# Altium schematic sheet 04_Connectors.SchDoc(04_Connectors)
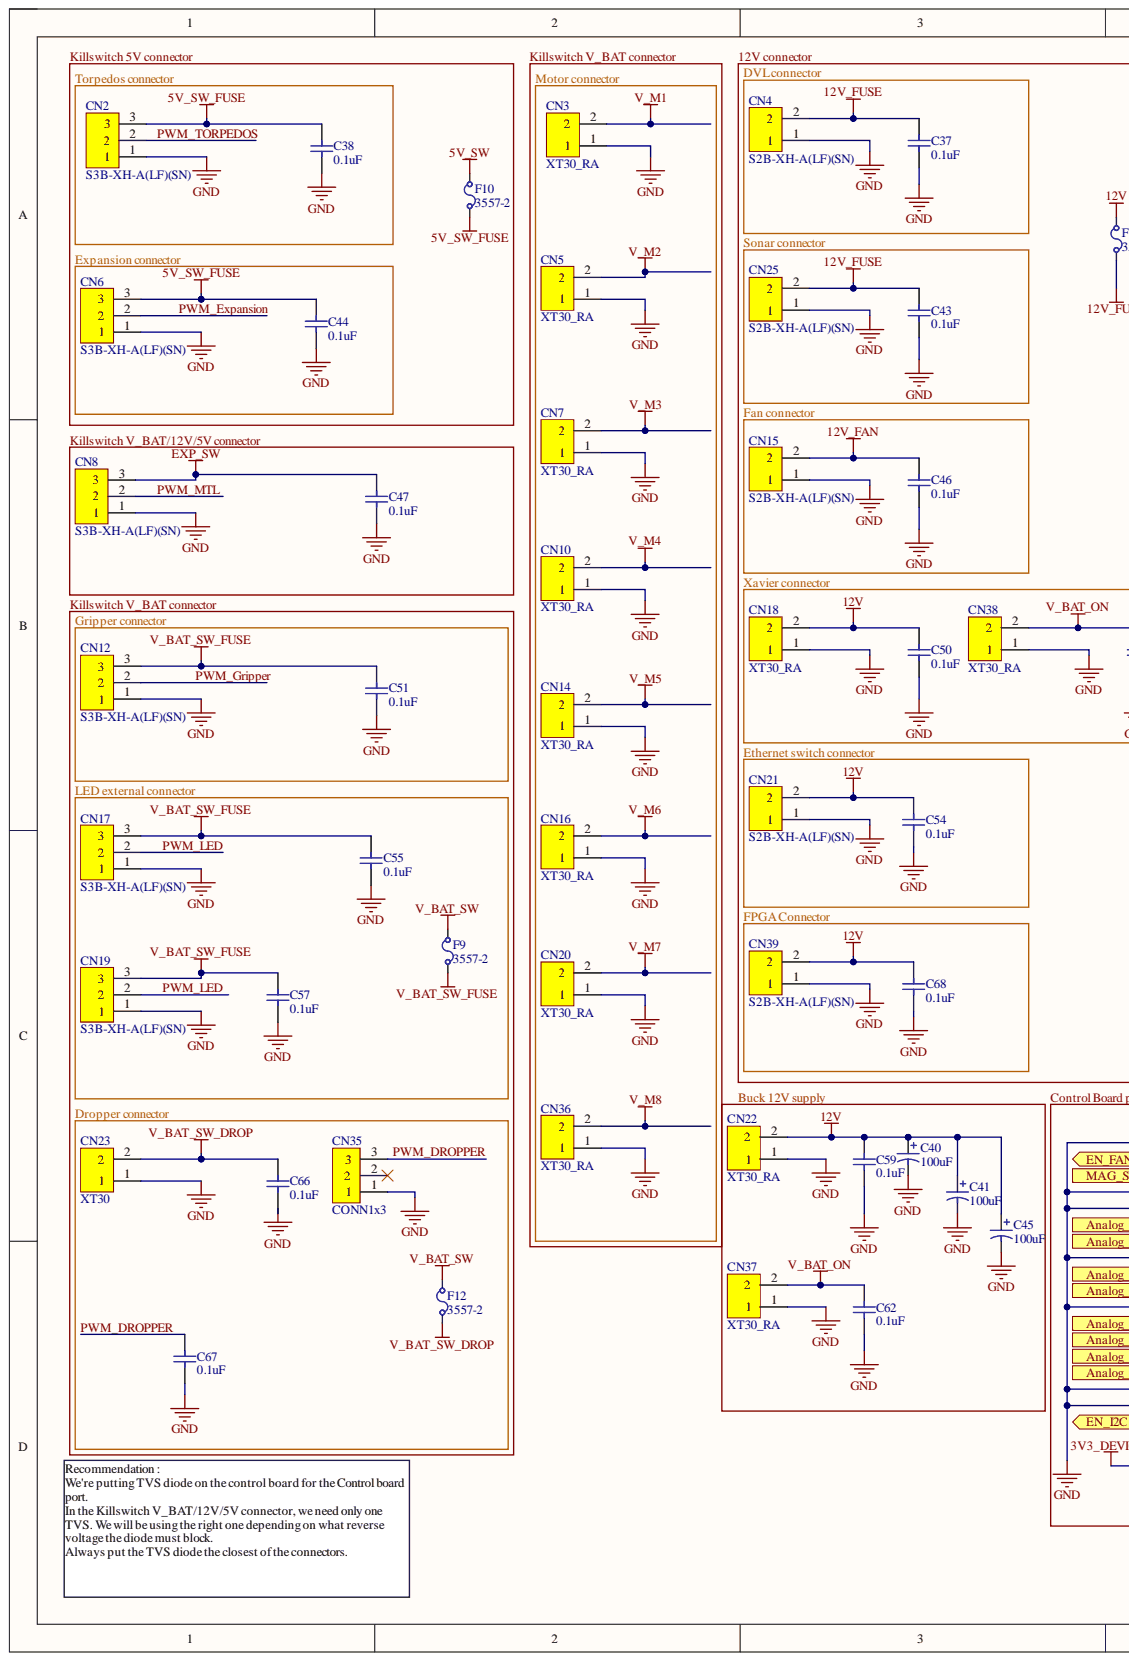
[diagram p0: 04_Connectors.SchDoc, left]
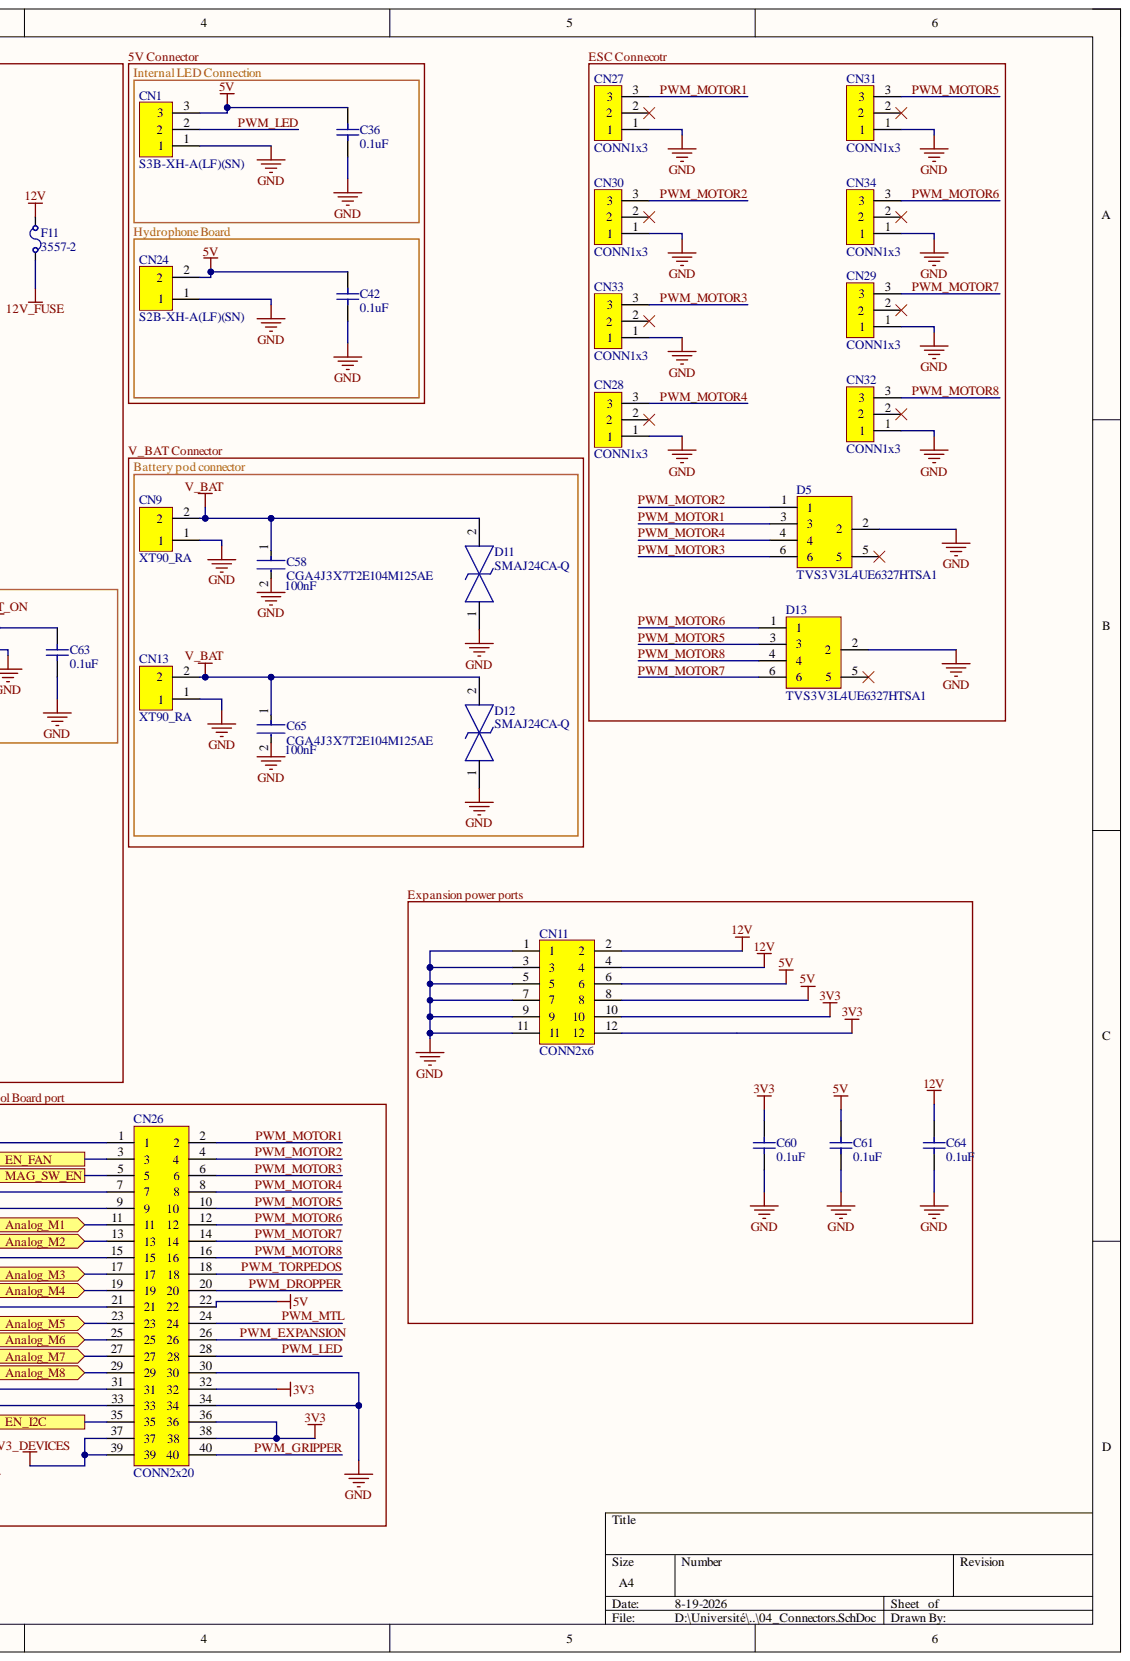
[diagram p1: 04_Connectors.SchDoc, right]

## Parts
C36  0.1uF  [CAPC200X125X145L50N]
C37  0.1uF  [CAPC200X125X145L50N]
C38  0.1uF  [CAPC200X125X145L50N]
C40  100uF  [CAPAE660X615D730L260X70N]
C41  100uF  [CAPAE660X615D730L260X70N]
C42  0.1uF  [CAPC200X125X145L50N]
C43  0.1uF  [CAPC200X125X145L50N]
C44  0.1uF  [CAPC200X125X145L50N]
C45  100uF  [CAPAE660X615D730L260X70N]
C46  0.1uF  [CAPC200X125X145L50N]
C47  0.1uF  [CAPC200X125X145L50N]
C50  0.1uF  [CAPC200X125X145L50N]
C51  0.1uF  [CAPC200X125X145L50N]
C54  0.1uF  [CAPC200X125X145L50N]
C55  0.1uF  [CAPC200X125X145L50N]
C57  0.1uF  [CAPC200X125X145L50N]
C58  CGA4J3X7T2E104M125AE  Capacitance: 100nF  [FP-CGA4J-IPC_C]  (no BOM)
C59  0.1uF  [CAPC200X125X145L50N]
C60  0.1uF  [CAPC200X125X145L50N]  (no BOM)
C61  0.1uF  [CAPC200X125X145L50N]  (no BOM)
C62  0.1uF  [CAPC200X125X145L50N]
C63  0.1uF  [CAPC200X125X145L50N]
C64  0.1uF  [CAPC200X125X145L50N]  (no BOM)
C65  CGA4J3X7T2E104M125AE  Capacitance: 100nF  [FP-CGA4J-IPC_C]  (no BOM)
C66  0.1uF  [CAPC200X125X145L50N]  (no BOM)
C67  0.1uF  [CAPC200X125X145L50N]  (no BOM)
C68  0.1uF  [CAPC200X125X145L50N]
CN1  S3B-XH-A(LF)(SN)  [JST_S3B-XH-A(LF)(SN)]  (no BOM)
CN2  S3B-XH-A(LF)(SN)  [JST_S3B-XH-A(LF)(SN)]  (no BOM)
CN3  XT30_RA  [XT30-90DEG]  (no BOM)
CN4  S2B-XH-A(LF)(SN)  [SHDRRA2W64P250_2X1_740X1150H610]  (no BOM)
CN5  XT30_RA  [XT30-90DEG]  (no BOM)
CN6  S3B-XH-A(LF)(SN)  [JST_S3B-XH-A(LF)(SN)]  (no BOM)
CN7  XT30_RA  [XT30-90DEG]  (no BOM)
CN8  S3B-XH-A(LF)(SN)  [JST_S3B-XH-A(LF)(SN)]  (no BOM)
CN9  XT90_RA  [XT90-90DEG]  (no BOM)
CN10  XT30_RA  [XT30-90DEG]  (no BOM)
CN11  CONN2x6  [RHDRV12W60P254_6X2_1575X495H851]  (no BOM)
CN12  S3B-XH-A(LF)(SN)  [JST_S3B-XH-A(LF)(SN)]  (no BOM)
CN13  XT90_RA  [XT90-90DEG]  (no BOM)
CN14  XT30_RA  [XT30-90DEG]  (no BOM)
CN15  S2B-XH-A(LF)(SN)  [SHDRRA2W64P250_2X1_740X1150H610]  (no BOM)
CN16  XT30_RA  [XT30-90DEG]  (no BOM)
CN17  S3B-XH-A(LF)(SN)  [JST_S3B-XH-A(LF)(SN)]  (no BOM)
CN18  XT30_RA  [XT30-90DEG]  (no BOM)
CN19  S3B-XH-A(LF)(SN)  [JST_S3B-XH-A(LF)(SN)]  (no BOM)
CN20  XT30_RA  [XT30-90DEG]  (no BOM)
CN21  S2B-XH-A(LF)(SN)  [SHDRRA2W64P250_2X1_740X1150H610]  (no BOM)
CN22  XT30_RA  [XT30-90DEG]  (no BOM)
CN23  XT30  [XT30 vertical]  (no BOM)
CN24  S2B-XH-A(LF)(SN)  [SHDRRA2W64P250_2X1_740X1150H610]  (no BOM)
CN25  S2B-XH-A(LF)(SN)  [SHDRRA2W64P250_2X1_740X1150H610]  (no BOM)
CN26  CONN2x20  [RHDRV40W102P254_20X2_5131X495H1613]  (no BOM)
CN27  CONN1x3  [HDRV3W60P254_3X1_813X254H851]  (no BOM)
CN28  CONN1x3  [HDRV3W60P254_3X1_813X254H851]  (no BOM)
CN29  CONN1x3  [HDRV3W60P254_3X1_813X254H851]  (no BOM)
CN30  CONN1x3  [HDRV3W60P254_3X1_813X254H851]  (no BOM)
CN31  CONN1x3  [HDRV3W60P254_3X1_813X254H851]  (no BOM)
CN32  CONN1x3  [HDRV3W60P254_3X1_813X254H851]  (no BOM)
CN33  CONN1x3  [HDRV3W60P254_3X1_813X254H851]  (no BOM)
CN34  CONN1x3  [HDRV3W60P254_3X1_813X254H851]  (no BOM)
CN35  CONN1x3  [HDRV3W60P254_3X1_813X254H851]  (no BOM)
CN36  XT30_RA  [XT30-90DEG]  (no BOM)
CN37  XT30_RA  [XT30-90DEG]  (no BOM)
CN38  XT30_RA  [XT30-90DEG]  (no BOM)
CN39  S2B-XH-A(LF)(SN)  [SHDRRA2W64P250_2X1_740X1150H610]  (no BOM)
D5  TVS3V3L4UE6327HTSA1  [SOP6P95_290X250X110L62X35N]
D11  SMAJ24CA-Q  [DIONM5127X229N]  (no BOM)
D12  SMAJ24CA-Q  [DIONM5127X229N]  (no BOM)
D13  TVS3V3L4UE6327HTSA1  [SOP6P95_290X250X110L62X35N]
F9  3557-2  [FUSE_3557-2]  (no BOM)
F10  3557-2  [FUSE_3557-2]  (no BOM)
F11  3557-2  [FUSE_3557-2]  (no BOM)
F12  3557-2  [FUSE_3557-2]  (no BOM)

## Ports
Analog_M1, Analog_M2, Analog_M3, Analog_M4, Analog_M5, Analog_M6, Analog_M7, Analog_M8, EN_FAN, EN_I2C, MAG_SW_EN

## Nets
3V3: C60.2 CN11.10 CN11.12 CN26.32 CN26.36 CN26.38
3V3_DEVICES: CN26.37 CN26.39
5V: C36.2 C42.1 C61.1 CN1.3 CN11.6 CN11.8 CN24.2 CN26.22
5V_SW: F10.2
5V_SW_FUSE: C38.2 C44.1 CN2.3 CN6.3 F10.1
12V: C40.1 C41.1 C45.1 C50.1 C54.1 C59.1 C64.1 C68.1 CN11.2 CN11.4 CN18.2 CN21.2 CN22.2 CN39.2 F11.2
12V_FAN: C46.1 CN15.2
12V_FUSE: C37.2 C43.1 CN4.2 CN25.2 F11.1
EXP_SW: C47.1 CN8.3
GND: C36.1 C37.1 C38.1 C40.2 C41.2 C42.2 C43.2 C44.2 C45.2 C46.2 C47.2 C50.2 C51.2 C54.2 C55.1 C57.1 C58.2 C59.2 C60.1 C61.2 C62.2 C63.2 C64.2 C65.2 C66.2 C67.2 C68.2 CN1.1 CN2.1 CN3.1 CN4.1 CN5.1 CN6.1 CN7.1 CN8.1 CN9.1 CN10.1 CN11.1 CN11.3 CN11.5 CN11.7 CN11.9 CN11.11 CN12.1 CN13.1 CN14.1 CN15.1 CN16.1 CN17.1 CN18.1 CN19.1 CN20.1 CN21.1 CN22.1 CN23.1 CN24.1 CN25.1 CN26.1 CN26.7 CN26.9 CN26.15 CN26.21 CN26.30 CN26.31 CN26.33 CN26.34 CN27.1 CN28.1 CN29.1 CN30.1 CN31.1 CN32.1 CN33.1 CN34.1 CN35.1 CN36.1 CN37.1 CN38.1 CN39.1 D5.2 D11.1 D12.1 D13.2
V_BAT: C58.1 C65.1 CN9.2 CN13.2 D11.2 D12.2
V_BAT_ON: C62.1 C63.1 CN37.2 CN38.2
V_BAT_SW: F9.1 F12.1
V_BAT_SW_DROP: C66.1 CN23.2 F12.2
V_BAT_SW_FUSE: C51.1 C55.2 C57.2 CN12.3 CN17.3 CN19.3 F9.2
V_M1: CN3.2
V_M2: CN5.2
V_M3: CN7.2
V_M4: CN10.2
V_M5: CN14.2
V_M6: CN16.2
V_M7: CN20.2
V_M8: CN36.2
Analog_M1: CN26.11 ; port Analog_M1
Analog_M2: CN26.13 ; port Analog_M2
Analog_M3: CN26.17 ; port Analog_M3
Analog_M4: CN26.19 ; port Analog_M4
Analog_M5: CN26.23 ; port Analog_M5
Analog_M6: CN26.25 ; port Analog_M6
Analog_M7: CN26.27 ; port Analog_M7
Analog_M8: CN26.29 ; port Analog_M8
EN_FAN: CN26.3 ; port EN_FAN
EN_I2C: CN26.35 ; port EN_I2C
MAG_SW_EN: CN26.5 ; port MAG_SW_EN
PWM_DROPPER: C67.1 CN26.20 CN35.3
PWM_Expansion: CN6.2 CN26.26
PWM_Gripper: CN12.2 CN26.40
PWM_LED: CN1.2 CN17.2 CN19.2 CN26.28
PWM_MOTOR1: CN26.2 CN27.3 D5.3
PWM_MOTOR2: CN26.4 CN30.3 D5.1
PWM_MOTOR3: CN26.6 CN33.3 D5.6
PWM_MOTOR4: CN26.8 CN28.3 D5.4
PWM_MOTOR5: CN26.10 CN31.3 D13.3
PWM_MOTOR6: CN26.12 CN34.3 D13.1
PWM_MOTOR7: CN26.14 CN29.3 D13.6
PWM_MOTOR8: CN26.16 CN32.3 D13.4
PWM_MTL: CN8.2 CN26.24
PWM_TORPEDOS: CN2.2 CN26.18

Not connected: CN27 2; CN28 2; CN29 2; CN30 2; CN31 2; CN32 2; CN33 2; CN34 2; CN35 2; D5 5; D13 5

## Library data of the parts
C36-C38 C42-C44 C46 C47 C50 C51 C54 C55 C57 C59-C64 C66-C68 = YAGEO_CC0805KRX7R9BB104: CAP CER 0.1UF 50V X7R 0805; Manufacturer: Yageo; Detailed description: ±10% 50V Ceramic Capacitor X7R 0805 (2012 Metric); Operating description: -55°C ~ 125°C; ComponentLink1Description: Datasheet; ComponentLink1URL: https://www.yageo.com/upload/media/product/productsearch/datasheet/mlcc/UPY-GPHC_X7R_6.3V-to-50V_18.pdf; LCSC_Part_Number: C49678; Library Name: PCB_Power.SchLib; Manufacturer part number: CC0805KRX7R9BB104; Price: 0.16; Supplier: Digikey; Supplier part number: 311-1140-1-ND; Value: 0.1uF
  C36-C38 C42-C44 C46 C47 C50 C51 C54 C55 C57 C59 C62 C63 C68: Component Kind: Standard
C40 C41 C45 = PEC_EEE-FK1E101XP: CAP ALUM 100UF 20% 25V SMD; Manufacturer: Panasonic Electronic Components; Detailed description: Aluminum Electrolytic Capacitors Radial, Can - SMD - 2000 Hrs @ 105°C; Component Kind: Standard; ComponentLink1Description: Datasheet; ComponentLink1URL: https://b2b-api.panasonic.eu/file_stream/pids/fileversion/3127; LCSC_Part_Number: C128510; Library Name: PCB_POWER.IntLib; Manufacturer part number: EEE-FK1E101XP; Operating temperature: -55°C ~ 105°C; Price: 0.66; Supplier: Digikey; Supplier part number: PCE3797CT-ND; Value: 100uF
C58 C65 = CMP-08246-000150-1: Cap Ceramic 100nF 250V X7T 20% Pad SMD 0805 +125°C Automotive T/R; Case Code (Imperial): 0805; Case Code (Metric): 2012; Case/Package: 0805; Depth: 1.25mm; Dielectric: X7T; Features: Soft Termination; Height: 1.25mm; LCSC_Part_Number: C2170948; Length: 2mm; Library Name: Altium Content Vault; Max Operating Temperature: 125°C; Min Operating Temperature: -55°C; Mount: Surface Mount; Package Quantity: 1; Packaging: Tape and Reel; Radiation Hardening: No; Ratings: AEC-Q200; REACH SVHC: No SVHC; Termination: SMD/SMT; Thickness: 0.049inch; Tolerance: 20%; Voltage Rating: 250V; Voltage Rating (DC): 250V; Weight: 0.000194oz; Width: 0.049inch
CN1 CN2 CN6 CN8 CN12 CN17 CN19 = JST_S3B-XH-A(LF)(SN): CONN HEADER R/A 3POS 2.5MM; Manufacturer: JST Sales America Inc.; Detailed description: Connector Header Through Hole, Right Angle 3 position 0.098" (2.50mm); ComponentLink1Description: Datasheet; ComponentLink1URL: http://www.jst-mfg.com/product/pdf/eng/eXH.pdf; LCSC_Part_Number: C157928; Library Name: PCB_Power.SchLib; Manufacturer part number: S3B-XH-A(LF)(SN); Operating temperature: -25°C ~ 85°C; Price: 0.31; Supplier: Digikey; Supplier part number: 455-2250-ND
CN3 CN5 CN7 CN10 CN14 CN16 CN18 CN20 CN22 CN36-CN38 = HM_XT30_RA: Manufacturer: Home made; LCSC_Part_Number: Ne_pas_ajouter; Library Name: PCB_Power.SchLib; Supplier: Amazon
CN4 CN15 CN21 CN24 CN25 CN39 = JST_S2B-XH-A(LF)(SN): CONN HEADER R/A 2POS 2.5MM; Manufacturer: JST Sales America Inc.; Detailed description: Connector Header position; ComponentLink1Description: Datasheet; ComponentLink1URL: http://www.jst-mfg.com/product/pdf/eng/eXH.pdf; LCSC_Part_Number: C157931; Library Name: PCB_Power.SchLib; Manufacturer part number: S2B-XH-A(LF)(SN); Operating temperature: -25°C ~ 85°C; Price: 0.24; Supplier: Digikey; Supplier part number: 455-2257-ND
CN9 CN13 = HM_XT90_RA: Manufacturer: Home made; LCSC_Part_Number: Ne_pas_ajouter; Library Name: PCB_Power.SchLib; Supplier: Amazon
CN11 = HM_CONN2x6: CONN SOCKET 12POS 0.1 TIN PCB; Manufacturer: Home made Bastien Cote; Detailed description: 12 Position Elevated Socket Connector  Through Hole; LCSC_Part_Number: A_Verifier; Library Name: PCB_Power.SchLib; Manufacturer part number: CONN2x6; Operating temperature: ???; Price: ???; Supplier: Ebay; Supplier part number: -
CN23 = HM_XT30: Manufacturer: Home made; LCSC_Part_Number: Ne_pas_ajouter; Library Name: PCB_Power.SchLib; Supplier: Amazon
CN26 = HM_CONN2x20: CONN SOCKET 40POS 0.1 TIN PCB; Manufacturer: Home made Bastien Cote; Detailed description: 40 Position Elevated Socket Connector  Through Hole; LCSC_Part_Number: A_Verifier; Library Name: PCB_Power.SchLib; Manufacturer part number: CONN2x20; Operating temperature: ???; Price: ???; Supplier: Ebay; Supplier part number: -
CN27-CN35 = HM_CONN1x3: CONN MALE 12POS 0.1 TIN PCB; Manufacturer: Home made Bastien Cote; Detailed description: 3 Position Elevated Socket Connector  Through Hole; LCSC_Part_Number: A_Verifier; Library Name: PCB_Power.SchLib; Manufacturer part number: CONN1x3; Operating temperature: ???; Price: ???; Supplier: Ebay; Supplier part number: -
D5 D13 = IT_TVS3V3L4UE6327HTSA1: TVS DIODE 3.3V 7.7V SC74; Manufacturer: Infineon Technologies; Detailed description: 7.7V (Typ) Clamp 20A (8/20µs) Ipp Tvs Diode Surface Mount PG-SC74-6; Component Kind: Standard; ComponentLink1Description: Datasheet; ComponentLink1URL: https://www.infineon.com/dgdl/TVS3V3L4U_rev_2_2.pdf?folderId=db3a30431f848401011fcbf2ab4c04c4&fileId=db3a3043382e837301385be7912532ed; LCSC_Part_Number: C132185; Library Name: PCB_Power.SchLib; Manufacturer part number: TVS3V3L4UE6327HTSA1; Operating temperature: -55°C ~ 125°C (TJ); Price: 0.67; Supplier: Digikey; Supplier part number: TVS3V3L4UE6327HTSA1CT-ND
D11 D12 = SMAJ24CA-Q: Bourns SMAJ24CA-Q, Bi-Directional TVS Diode, 400W, 2-Pin DO-214AC; Geometry.Height: 2.29mm; Datasheet Link: https://www.bourns.com/docs/Product-Datasheets/SMAJ-Q.pdf; LCSC_Part_Number: C1973119; Library Name: Protection_Lib.SchLib; Manufacturer_Name: Bourns; Manufacturer_Part_Number: SMAJ24CA-Q; Mouser Part Number: 652-SMAJ24CA-Q; Mouser Price/Stock: https://www.mouser.co.uk/ProductDetail/Bourns/SMAJ24CA-Q?qs=f9yNj16SXrJFTMu%2Ffx4vHg%3D%3D
F9-F12 = Keystone_3557-2: FUSE BLOCK BLADE 500V 30A PCB; Manufacturer: Keystone Electronics; Area: 133.32; Detailed Description: Fuse Block 500V Circuit Blade Through Hole; ComponentLink1Description: Datasheet; ComponentLink1URL: http://keyelco.com/userAssets/file/M65p41.pdf; LCSC_Part_Number: Ne_pas_ajouter; Library Name: PCB_Power.SchLib; Manufacturer Part Number: 3557-2; Operating Temperature: -; Price: 1.78; Supplier: Digikey; Supplier Part Number: 36-3557-2-ND
